# Altium schematic sheet MCU.SchDoc(MCU)
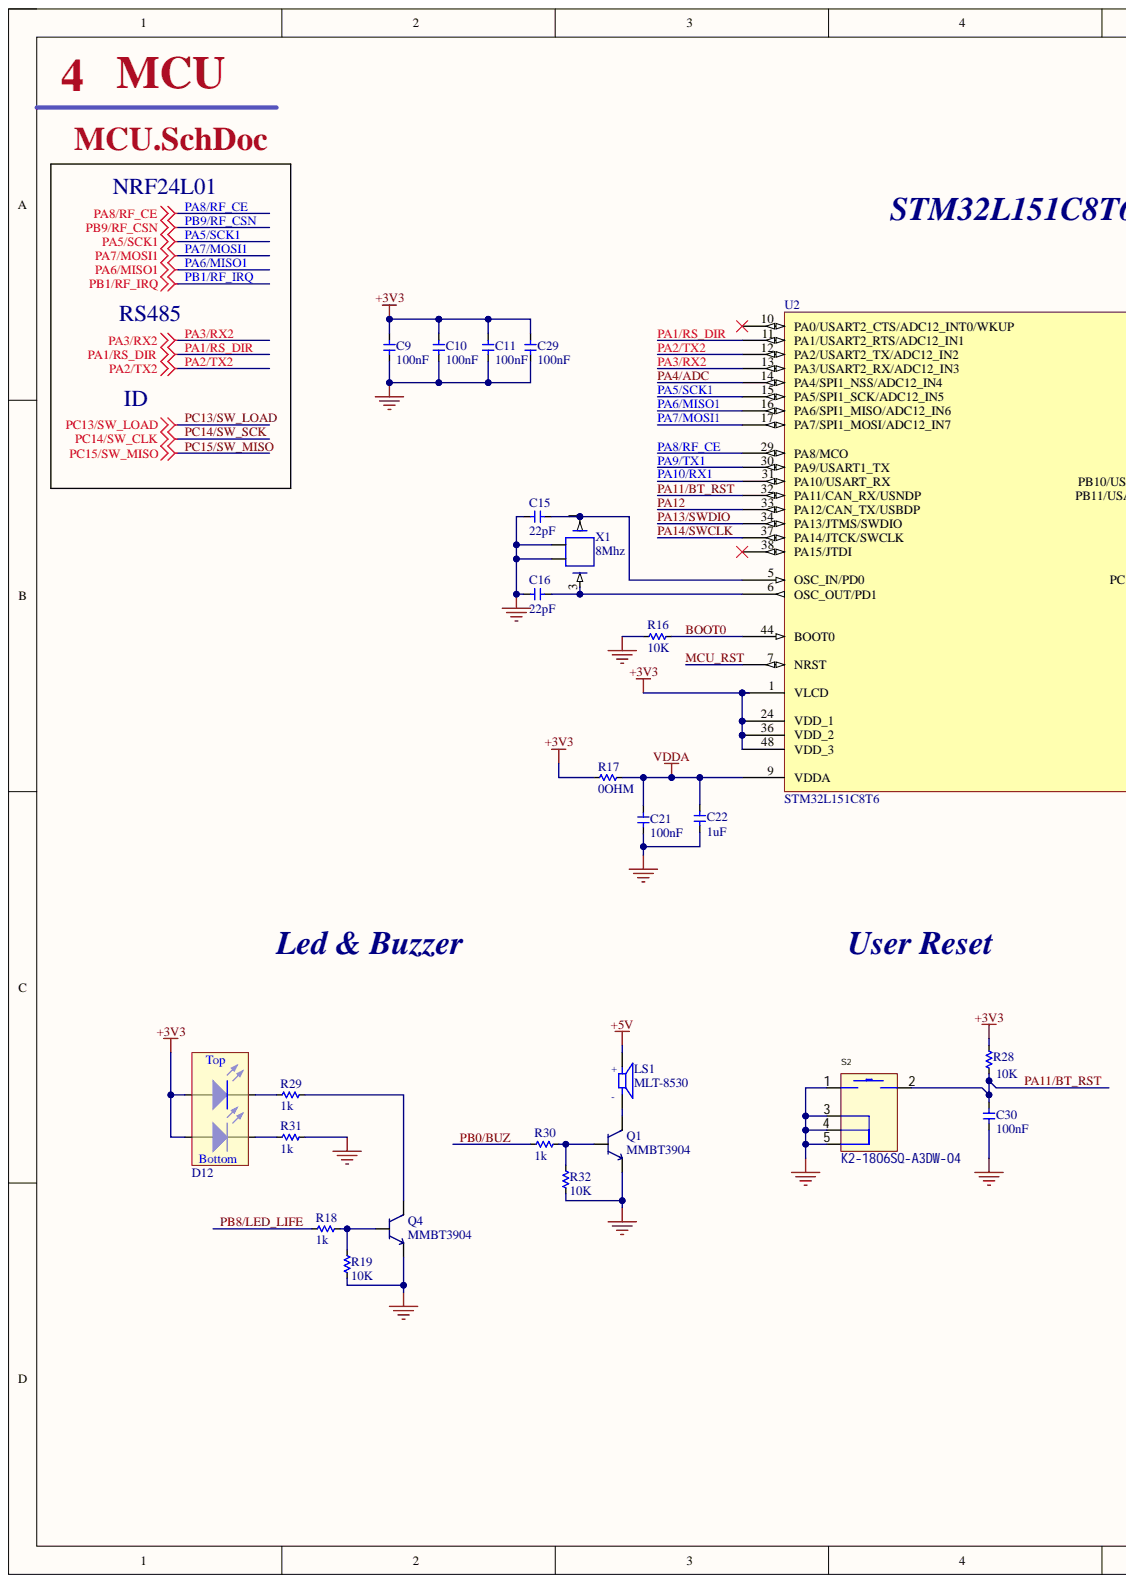
[diagram p0: MCU.SchDoc, left]
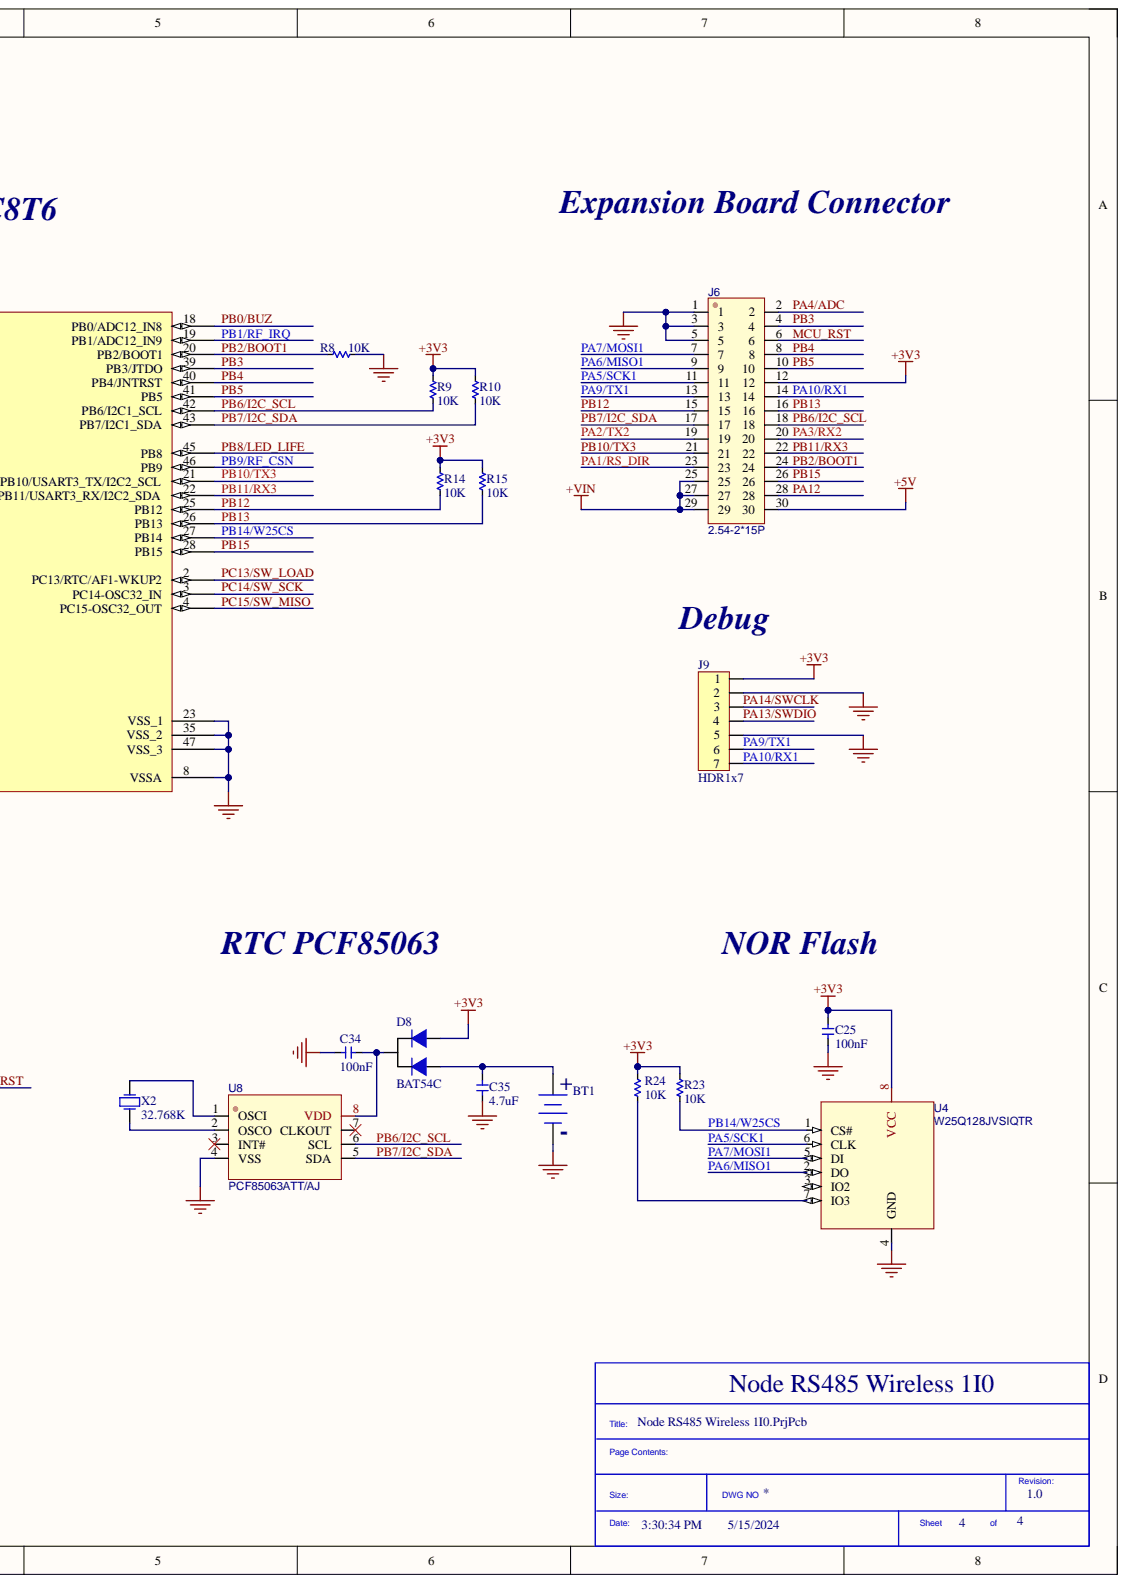
[diagram p1: MCU.SchDoc, right]

## Parts
BT1  CR1220-2ZX  [BAT_CR1220_SMD]  (value not drawn)
C9  100nF  [C_0402]
C10  100nF  [C_0402]
C11  100nF  [C_0402]
C15  22pF  [C_0402]
C16  22pF  [C_0402]
C21  100nF  [C_0402]
C22  1uF  [C_0603]
C25  100nF  [C_0402]
C29  100nF  [C_0402]
C30  100nF  [C_0402]
C34  100nF  [C_0402]
C35  4.7uF  [C_0603]
D8  BAT54C  [SOT-23-3_L2.9-W1.6-P1.90-LS2.8-BR]
D12  2LED_3MM  [2LED_3MM]  (value not drawn)
J6  2.54-2*15P  [HDR-TH_30P-P2.54-V-F-R2-C15-S2.54]
J9  HDR1x7  [HDR1X7H]
LS1  MLT-8530  [BUZ-SMD_4P-L8.5-W8.5-P8.50-BR]
Q1  MMBT3904  [SOT-23-3_L2.9-W1.3-P1.90-LS2.4-BR]
Q4  MMBT3904  [SOT-23-3_L2.9-W1.3-P1.90-LS2.4-BR]
R8  10K  [R_0402]
R9  10K  [R_0402]
R10  10K  [R_0402]
R14  10K  [R_0402]
R15  10K  [R_0402]
R16  10K  [R_0402]
R17  0OHM  [R_0603]
R18  1k  [R_0402]
R19  10K  [R_0402]
R23  10K  [R_0402]
R24  10K  [R_0402]
R28  10K  [R_0402]
R29  1k  [R_0402]
R30  1k  [R_0402]
R31  1k  [R_0402]
R32  10K  [R_0402]
S2  K2-1806SQ-A3DW-04  [KEY-SMD_K2-1806SQ-A3DW-04]
U2  STM32L151C8T6  [LQFP-48_L7.0-W7.0-P0.50-LS9.0-BL]
U4  W25Q128JVSIQTR  [SOIC-8_L5.3-W5.3-P1.27-LS8.0-BL]
U8  PCF85063ATT/AJ  [TSSOP-8_L3.0-W3.0-P0.65-LS4.9-BL]
X1  8Mhz  [SMD-3225_4P]
X2  32.768K  [SMD-3215_2P]

## Nets
+3V3: C9.2 C10.2 C11.2 C25.1 C29.2 D8.2 D12.1 D12.3 J6.12 J9.1 R9.1 R10.1 R14.1 R15.1 R17.2 R23.1 R24.1 R28.1 U2.1(VLCD) U2.24(VDD_1) U2.36(VDD_2) U2.48(VDD_3) U4.8(VCC)
+5V: J6.30 LS1.1
+VIN: J6.25 J6.27 J6.29
GND: BT1.2 C9.1 C10.1 C11.1 C15.2 C16.2 C21.1 C22.1 C25.2 C29.1 C30.1 C34.2 C35.1 J6.1 J6.3 J6.5 J9.2 J9.5 Q1.2 Q4.2 R8.1 R16.1 R19.2 R31.2 R32.2 S2.1 S2.3 S2.4 S2.5 U2.8(VSSA) U2.23(VSS_1) U2.35(VSS_2) U2.47(VSS_3) U4.4(GND) U8.4(VSS) X1.2 X1.4
VDDA: C21.2 C22.2 R17.1 U2.9(VDDA)
BOOT0: R16.2 U2.44(BOOT0)
MCU_RST: J6.6 U2.7(NRST)
PA1/RS_DIR: J6.23 U2.11(PA1/USART2_RTS/ADC12_IN1)
PA2/TX2: J6.19 U2.12(PA2/USART2_TX/ADC12_IN2)
PA3/RX2: J6.20 U2.13(PA3/USART2_RX/ADC12_IN3)
PA4/ADC: J6.2 U2.14(PA4/SPI1_NSS/ADC12_IN4)
PA5/SCK1: J6.11 U2.15(PA5/SPI1_SCK/ADC12_IN5) U4.6(CLK)
PA6/MISO1: J6.9 U2.16(PA6/SPI1_MISO/ADC12_IN6) U4.2(DO)
PA7/MOSI1: J6.7 U2.17(PA7/SPI1_MOSI/ADC12_IN7) U4.5(DI)
PA8/RF_CE: U2.29(PA8/MCO)
PA9/TX1: J6.13 J9.6 U2.30(PA9/USART1_TX)
PA10/RX1: J6.14 J9.7 U2.31(PA10/USART_RX)
PA11/BT_RST: C30.2 R28.2 S2.2 U2.32(PA11/CAN_RX/USNDP)
PA12: J6.28 U2.33(PA12/CAN_TX/USBDP)
PA13/SWDIO: J9.4 U2.34(PA13/JTMS/SWDIO)
PA14/SWCLK: J9.3 U2.37(PA14/JTCK/SWCLK)
PB0/BUZ: R30.1 U2.18(PB0/ADC12_IN8)
PB1/RF_IRQ: U2.19(PB1/ADC12_IN9)
PB2/BOOT1: J6.24 R8.2 U2.20(PB2/BOOT1)
PB3: J6.4 U2.39(PB3/JTDO)
PB4: J6.8 U2.40(PB4/JNTRST)
PB5: J6.10 U2.41(PB5)
PB6/I2C_SCL: J6.18 R9.2 U2.42(PB6/I2C1_SCL) U8.6(SCL)
PB7/I2C_SDA: J6.17 R10.2 U2.43(PB7/I2C1_SDA) U8.5(SDA)
PB8/LED_LIFE: R18.1 U2.45(PB8)
PB9/RF_CSN: U2.46(PB9)
PB10/TX3: J6.21 U2.21(PB10/USART3_TX/I2C2_SCL)
PB11/RX3: J6.22 U2.22(PB11/USART3_RX/I2C2_SDA)
PB12: J6.15 R14.2 U2.25(PB12)
PB13: J6.16 R15.2 U2.26(PB13)
PB14/W25CS: R23.2 U2.27(PB14) U4.1(CS#)
PB15: J6.26 U2.28(PB15)
PC13/SW_LOAD: U2.2(PC13/RTC/AF1-WKUP2)
PC14/SW_SCK: U2.3(PC14-OSC32_IN)
PC15/SW_MISO: U2.4(PC15-OSC32_OUT)
NetBT1_1: BT1.1 C35.2 D8.1
NetC15_1: C15.1 U2.5(OSC_IN/PD0) X1.1
NetC16_1: C16.1 U2.6(OSC_OUT/PD1) X1.3
NetC34_1: C34.1 D8.3 U8.8(VDD)
NetD12_2: D12.2 R31.1
NetD12_4: D12.4 R29.1
NetLS1_2: LS1.2 Q1.3
NetQ1_1: Q1.1 R30.2 R32.1
NetQ4_1: Q4.1 R18.2 R19.1
NetQ4_3: Q4.3 R29.2
NetR24_2: R24.2 U4.7(IO3)
NetU8_1: U8.1(OSCI) X2.2
NetU8_2: U8.2(OSCO) X2.1

Not connected: U2 10(PA0/USART2_CTS/ADC12_INT0/WKUP) 38(PA15/JTDI); U4 3(IO2); U8 3(INT#) 7(CLKOUT)

## Library data of the parts
BT1 = CR1220-2ZX: SMD Battery Holders, Clips & Contacts RoHS 1220 batteries; Manufacturer: Q&J; Assembly Type: SMT Assembly; Component Kind: Standard; ComponentLink1Description: https://datasheet.ciiva.com/16220/m60-2p2-16220480.pdf?src-supplier=Future+Electronics; ComponentLink1URL: https://datasheet.ciiva.com/16220/m60-2p2-16220480.pdf?src-supplier=Future+Electronics; ComponentLink2Description: https://datasheet.ciiva.com/18491/1056-18491289.pdf?src-supplier=Digi-Key; ComponentLink2URL: https://datasheet.ciiva.com/18491/1056-18491289.pdf?src-supplier=Digi-Key; ComponentLink3Description: https://datasheet.ciiva.com/26923/getdatasheetpartid-755844-26923850.pdf?src-supplier=Verical; ComponentLink3URL: https://datasheet.ciiva.com/26923/getdatasheetpartid-755844-26923850.pdf?src-supplier=Verical; LCSC Part Number: C969906; Library Name: AK Base Kit 2.2.SCHLIB; Manufacturer Part Number: CR1220-2ZX; Package: SMD
C9-C11 C21 C25 C29 C30 C34 = 0402B104K160NT: 100nF 16V X7R ±10% 0402 Multilayer Ceramic Capacitors MLCC - SMD/SMT ROH; Manufacturer: FH(Guangdong Fenghua Advanced Tech); Assembly Type: SMT Assembly; Component Kind: Standard; ComponentLink1Description: Digikey Link; ComponentLink2Description: LCSC Link; ComponentLink2URL: https://jlcpcb.com/parts/componentSearch?searchTxt=10k%200603; LCSC Part Number: C41851; Library Name: Dev Board STM OLED 2.0.SCHLIB; Manufacturer Part Number: 0402B104K160NT; Package: 0402
C15 C16 = 0402CG220J500NT: 22pF 50V C0G ±5% 0402 Multilayer Ceramic Capacitors MLCC - SMD/SMT ROHS; Manufacturer: FH(Guangdong Fenghua Advanced Tech); Assembly Type: SMT Assembly; Component Kind: Standard; ComponentLink1Description: Digikey Link; ComponentLink2Description: LCSC Link; ComponentLink2URL: https://jlcpcb.com/parts/componentSearch?searchTxt=10k%200603; LCSC Part Number: C1555; Library Name: Dev Board STM OLED 2.0.SCHLIB; Manufacturer Part Number: 0402CG220J500NT; Package: 0402
C22 = CL10A105KB8NNNC: 1UF 50V 0603 ROHS; Manufacturer: Samsung Electro-Mechanics; Assembly Type: SMT Assembly; Component Kind: Standard; ComponentLink1Description: Digikey Link; ComponentLink1URL: https://www.digikey.com/en/products/detail/samsung-electro-mechanics/CL10A105KB8NNNC/3887518?s=N4IgTCBcDaIMIBkCMAGAgqgrAaQEIA4A5YuEAXQF8g; ComponentLink2Description: LCSC Link; ComponentLink2URL: https://jlcpcb.com/parts/componentSearch?searchTxt=10k%200603; LCSC Part Number: C15849; Library Name: Dev Board STM OLED 2.0.SCHLIB; Manufacturer Part Number: CL10A105KB8NNNC; Package: 0603
C35 = CL10A475KO8NNNC: 4.7UF 16V 0603 ROHS; Manufacturer: Samsung Electro-Mechanics; Assembly Type: SMT Assembly; Component Kind: Standard; LCSC Part Number: C19666; Library Name: Dev Board STM OLED 2.1.SCHLIB; Manufacturer Part Number: CL10A475KO8NNNC; Package: 0603
D8 = BAT54CLT1G: SCHOTTKY BARRIER DIODES (SBD) SOT-23(SOT-23-3) ROHS; Manufacturer: ON Semicon; Assembly Type: SMT Assembly; Component Kind: Standard; LCSC Part Number: C14308; Library Name: Dev Board STM OLED 2.1.SCHLIB; Manufacturer Part Number: BAT54CLT1G; Package: SOT-23-3
D12 = 2LED3MM: LED DUAL RED/GREEN DIFFUSED T/H; Manufacturer: Lumex; Manufacturer Part Number: SSF-LXH240IGD; Assembly Type: Hand Soldering; Component Kind: Standard; ComponentLink1Description: https://datasheet.ciiva.com/16387/lumex20ssf-lxh240igd-16387412.pdf?src-supplier=Future+Electronics; ComponentLink1URL: https://datasheet.ciiva.com/16387/lumex20ssf-lxh240igd-16387412.pdf?src-supplier=Future+Electronics; ComponentLink2Description: https://datasheet.ciiva.com/4673/ssf-lxh240igd-59870-4673513.pdf?src-supplier=Mouser; ComponentLink2URL: https://datasheet.ciiva.com/4673/ssf-lxh240igd-59870-4673513.pdf?src-supplier=Mouser; ComponentLink3Description: https://datasheet.ciiva.com/14605/70127278-14605558.pdf?src-supplier=Allied+Electronics; ComponentLink3URL: https://datasheet.ciiva.com/14605/70127278-14605558.pdf?src-supplier=Allied+Electronics; ComponentLink4Description: https://datasheet.ciiva.com/16840/70127280-16840571.pdf?src-supplier=Allied+Electronics; ComponentLink4URL: https://datasheet.ciiva.com/16840/70127280-16840571.pdf?src-supplier=Allied+Electronics; ComponentLink5Description: https://datasheet.ciiva.com/1535/ssf-lxh240igd-1535964.pdf?src-supplier=Element14; ComponentLink5URL: https://datasheet.ciiva.com/1535/ssf-lxh240igd-1535964.pdf?src-supplier=Element14; ComponentLink6Description: https://datasheet.ciiva.com/1644/ssf-lxh240igd-1644716.pdf?src-supplier=Farnell; ComponentLink6URL: https://datasheet.ciiva.com/1644/ssf-lxh240igd-1644716.pdf?src-supplier=Farnell; ComponentLink7Description: https://datasheet.ciiva.com/1536/ssf-lxh240igd-1536046.pdf?src-supplier=Element14; ComponentLink7URL: https://datasheet.ciiva.com/1536/ssf-lxh240igd-1536046.pdf?src-supplier=Element14; ComponentLink8Description: https://datasheet.ciiva.com/1746/ssf-lxh240igd-1746846.pdf?src-supplier=Newark; ComponentLink8URL: https://datasheet.ciiva.com/1746/ssf-lxh240igd-1746846.pdf?src-supplier=Newark; ComponentLink9Description: http://datasheet.octopart.com/SSF-LXH240IGD-Lumex-datasheet-60839.pdf?src-supplier=Element14; ComponentLink9URL: http://datasheet.octopart.com/SSF-LXH240IGD-Lumex-datasheet-60839.pdf?src-supplier=Element14; ComponentLink10Description: http://datasheet.octopart.com/SSF-LXH240IGD-Lumex-datasheet-9743625.pdf?src-supplier=Allied+Electronics; ComponentLink10URL: http://datasheet.octopart.com/SSF-LXH240IGD-Lumex-datasheet-9743625.pdf?src-supplier=Allied+Electronics; LCSC Part Number: N/A; Library Name: Node RS485 Wireless 1I0.SCHLIB; Package: Through Hole
J6 = 2.54-2*15P: Straight,P=2.54mm  Female Headers ROHS; Manufacturer: BOOMELE(博穆精密); Assembly Type: SMT Assembly; Component Kind: Standard; LCSC Part Number: C5337168; Library Name: Node RS485 Wireless 1I0.SCHLIB; Manufacturer Part Number: 2.54-2*15P; Package: Straight,P=2.54mm
J9 = Header 1x7: Header IDC, 1x8 Pin horizontal 2.54mm Pitch (Non-Boxed, Male); Manufacturer: China; Manufacturer Part Number: HDR1x7 Horizonal; ComponentLink1 Description: Manufacturer; ComponentLink2 Description: Datasheet; Assembly Type: Hand Soldering; Component Kind: Standard; ComponentLink1URL: http://www.we-online.com; ComponentLink2URL: http://katalog.we-online.de/em/datasheet/6130xx143121.pdf; Library Name: AK Base Kit 2.2.SCHLIB; Package: P=2.54
LS1 = BUZ_MLT-8530: BUZZERS MAGNETIC NO 2700HZ 3.6V 2.5 ~ 4.5V 8.5MM X 8.5MM 8.5*8.5*3MM ROHS; Manufacturer: Jiangsu Huaneng Elec; Assembly Type: SMT Assembly; Component Kind: Standard; LCSC Part Number: C94599; Library Name: Dev Board STM OLED 2.0.SCHLIB; Manufacturer Part Number: MLT-8530; Package: SMD-4_8.7x8.7x3.0
Q1 Q4 = MMBT3904: MMBT3904 TRANSISTORS (NPN/PNP) NPN 200MA 40V SOT-23(SOT-23-3) ROHS; Manufacturer: Changjiang Electronics Tech (CJ); Assembly Type: SMT Assembly; Component Kind: Standard; LCSC Part Number: C20526; Library Name: Dev Board STM OLED 2.0.SCHLIB; Manufacturer Part Number: MMBT3904; Package: SOT-23-3
R8-R10 R14-R16 R19 R23 R24 R28 R32 = 0402WGF1002TCE: 10kΩ 0402 62.5mW Thick Film Resistors 50V ±100ppm/℃ ±1% -55℃~+155℃; Manufacturer: Uniroyal Elec; Assembly Type: SMT Assembly; Component Kind: Standard; LCSC Part Number: C25744; Library Name: Dev Board STM OLED 2.0.SchLib; Manufacturer Part Number: 0402WGF1002TCE; Package: 0402
R17 = 0603WAF0000T5E: 0OHMS ±1% 1/10W 0603 ROHS; Manufacturer: Uniroyal Elec; Assembly Type: SMT Assembly; Component Kind: Standard; LCSC Part Number: C21189; Library Name: Dev Board STM OLED 2.0.SCHLIB; Manufacturer Part Number: 0603WAF0000T5E; Package: 0603
R18 R29-R31 = 0402WGF1001TCE: 1kΩ 0402 62.5mW Thick Film Resistors 50V ±100ppm/℃ ±1% -55℃~+155℃; Manufacturer: Uniroyal Elec; Assembly Type: SMT Assembly; Component Kind: Standard; LCSC Part Number: C11702; Library Name: AK Base Kit 2.2.SCHLIB; Manufacturer Part Number: 0402WGF1001TCE; Package: 0402
S2 = K2-1806SQ-A3DW-04: 50mA Surface Mount SPST 100MΩ 100000 Times 180gf@±30gf 12V SMD  Tactile Switches ROHS; Manufacturer: 韩国韩荣; Actuator Style: Rectangle button; Add into BOM: yes; Mechanical Life: 10万次; Operating Force: 180gf; Switch Height: 2.85mm; Switch Length: 3.95mm; Switch Width: 2mm; Assembly Type: SMT Assembly; Circuit: SPST; Component Kind: Standard; Contact Current: 50mA; Convert to PCB: yes; Datasheet: https://atta.szlcsc.com/upload/public/pdf/source/20210810/5AE433B363E6AE3A40B8960EE38EEDF9.pdf; Device: K2-1806SQ-A3DW-04; Insulation Resistance: 100MΩ; LCSC Part Name: 双支架侧按开关 3.95mm*2.0mm; LCSC Part Number: C128945; Library Name: Node RS485 Wireless 1I0.SCHLIB; Manufacturer Part Number: K2-1806SQ-A3DW-04; Mounting Style: 卧贴; Operating Temperature: -25℃~+85℃; Package: SMD; Supplier: LCSC; Symbol: K2-1806SQ-A3DW-04; Voltage Rating (DC): 12V; With Lamp: -
U2 = STM32L151C8T6: STM32 ARM-based 32-bit MCU with 64 Kbytes Flash, 48-pin LQFP, Industrial Temperature; Manufacturer: STMicroelectronics; Assembly Type: SMT Assembly; Component Kind: Standard; ComponentLink1Description: Manufacturer Link; ComponentLink1URL: www.st.com/stm32; ComponentLink2Description: Datasheet; ComponentLink2URL: http://www.st.com/stonline/products/literature/ds/13587.pdf; ComponentLink3Description: https://datasheet.ciiva.com/3220/lm2734-3220071.pdf?src-supplier=Avnet; ComponentLink3URL: https://datasheet.ciiva.com/3220/lm2734-3220071.pdf?src-supplier=Avnet; ComponentLink4Description: https://datasheet.ciiva.com/3220/lm2734-3220072.pdf?src-supplier=Avnet; ComponentLink4URL: https://datasheet.ciiva.com/3220/lm2734-3220072.pdf?src-supplier=Avnet; ComponentLink5Description: https://datasheet.ciiva.com/6598/lm2734-6598009.pdf?src-supplier=Digi-Key; ComponentLink5URL: https://datasheet.ciiva.com/6598/lm2734-6598009.pdf?src-supplier=Digi-Key; ComponentLink6Description: https://datasheet.ciiva.com/16536/lm2734-16536154.pdf?src-supplier=Avnet+Asia; ComponentLink6URL: https://datasheet.ciiva.com/16536/lm2734-16536154.pdf?src-supplier=Avnet+Asia; ComponentLink7Description: New link; LCSC Part Number: C9861; Library Name: AK Base Kit 2.2.SCHLIB; Manufacturer Part Number: STM32L151C8T6; Package: LQFP-48_7.0x7.0x0.5P Copy
U4 = W25Q128JVSIQTR: W25Q128JVSIQTR 128Mbit SOP-8-208mil NOR FLASH ROHS; Manufacturer: WINBOND; Assembly Type: SMT Assembly; Component Kind: Standard; LCSC Part Number: C97521; Library Name: Node RS485 Wireless 1I0.SCHLIB; Manufacturer Part Number: W25Q128JVSIQ; Package: SOP-8-208mil
U8 = PCF85063ATT/AJ: TSSOP-8-3.0mm Real-time Clocks (RTC) ROHS; Manufacturer: NXP; Assembly Type: AMT Assembly; Component Kind: Standard; LCSC Part Number: C165608; Library Name: AK Base Kit 2.1.SCHLIB; Manufacturer Part Number: PCF85063ATT/AJ; Package: TSSOP-8-3.0mm
X1 = 1C208000CE0Q: 8Mhz 3225 SMD CRYSTAL RESONATORS 8MHZ SMD-3225-4P ROHS; Manufacturer: KDS Daishinku; Assembly Type: SMT Assembly; Component Kind: Standard; LCSC Part Number: C133366; Library Name: Dev Board STM OLED 2.0.SCHLIB; Manufacturer Part Number: 1C208000CE0Q; Package: SMD-3225_4P
X2 = XTAL_32KHZ_3215: 32.768K SMD CRYSTAL RESONATORS 32.768KHZ ±20PPM SMD-3215_2P ROHS; Manufacturer: Seiko Epson; Manufacturer Part Number: Q13FC1350000400; Assembly Type: SMT Assembly; Component Kind: Standard; LCSC Part Number: C32346; Library Name: AK Base Kit 2.2.SCHLIB; Package: SMD-3215_2P
